# Altium schematic sheet Analog_Interface.SchDoc(Analog_Interface)
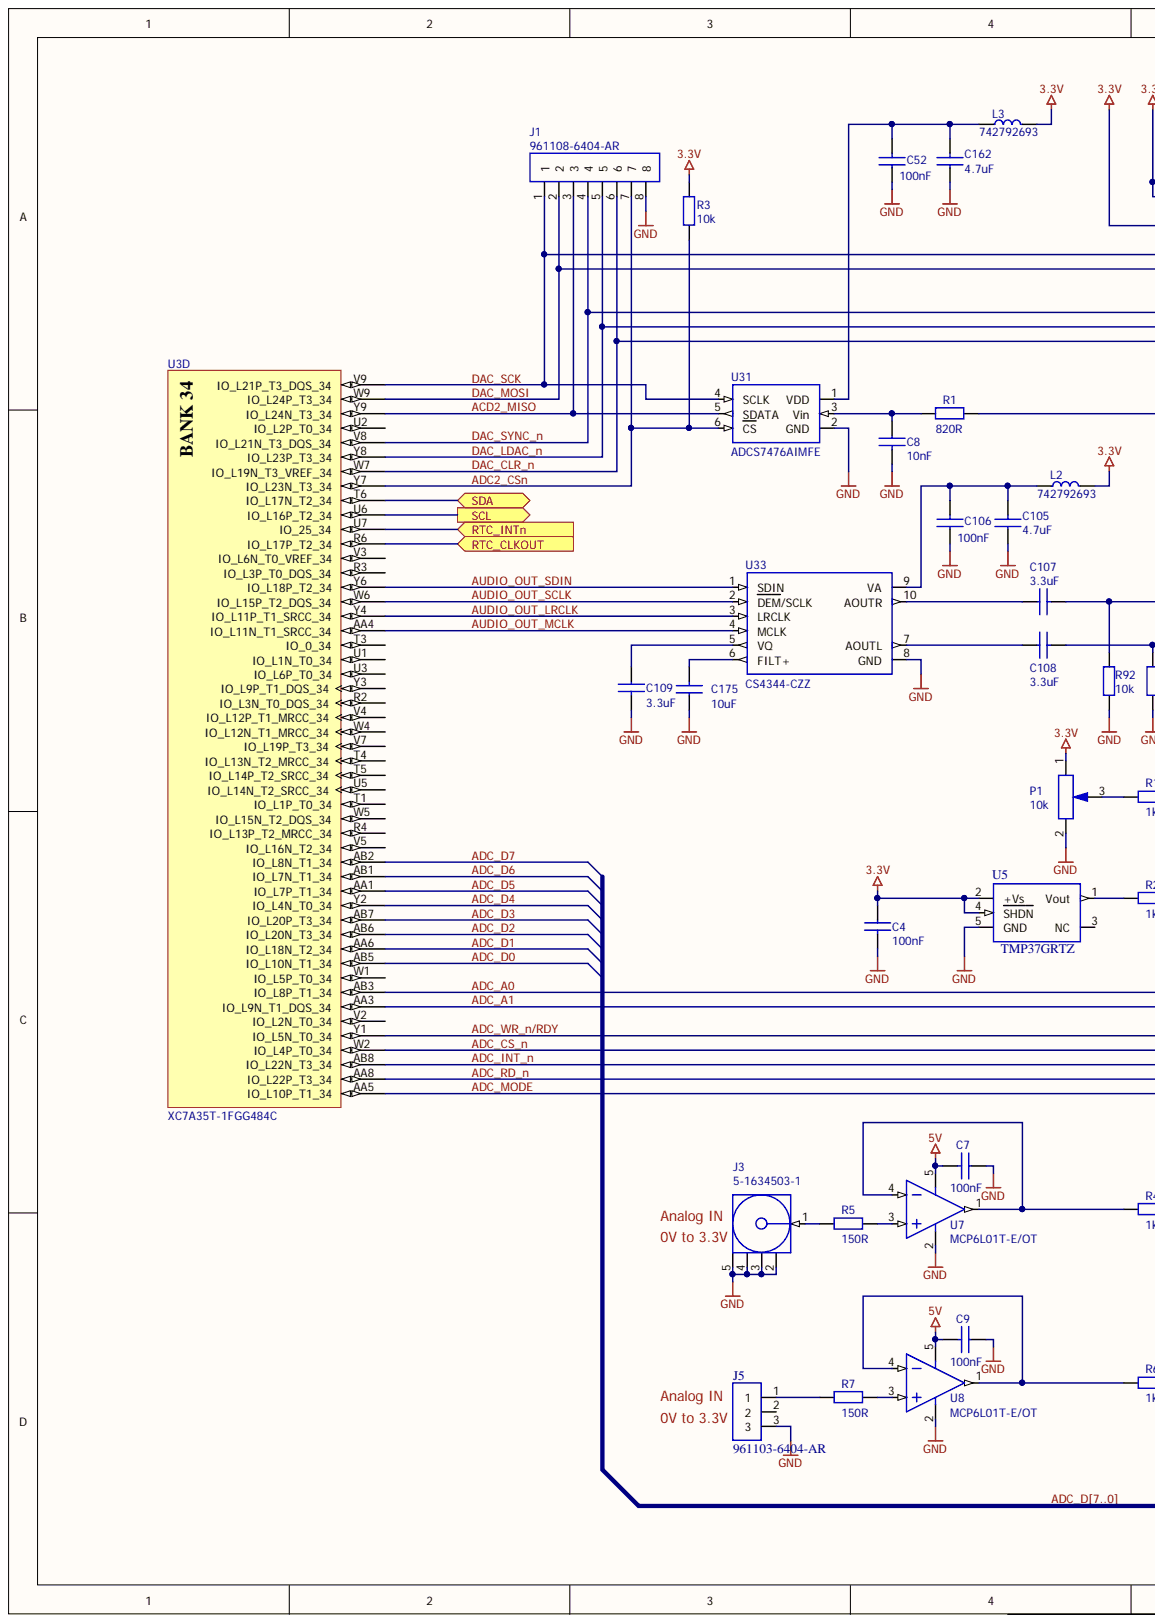
[diagram p0: Analog_Interface.SchDoc, left]
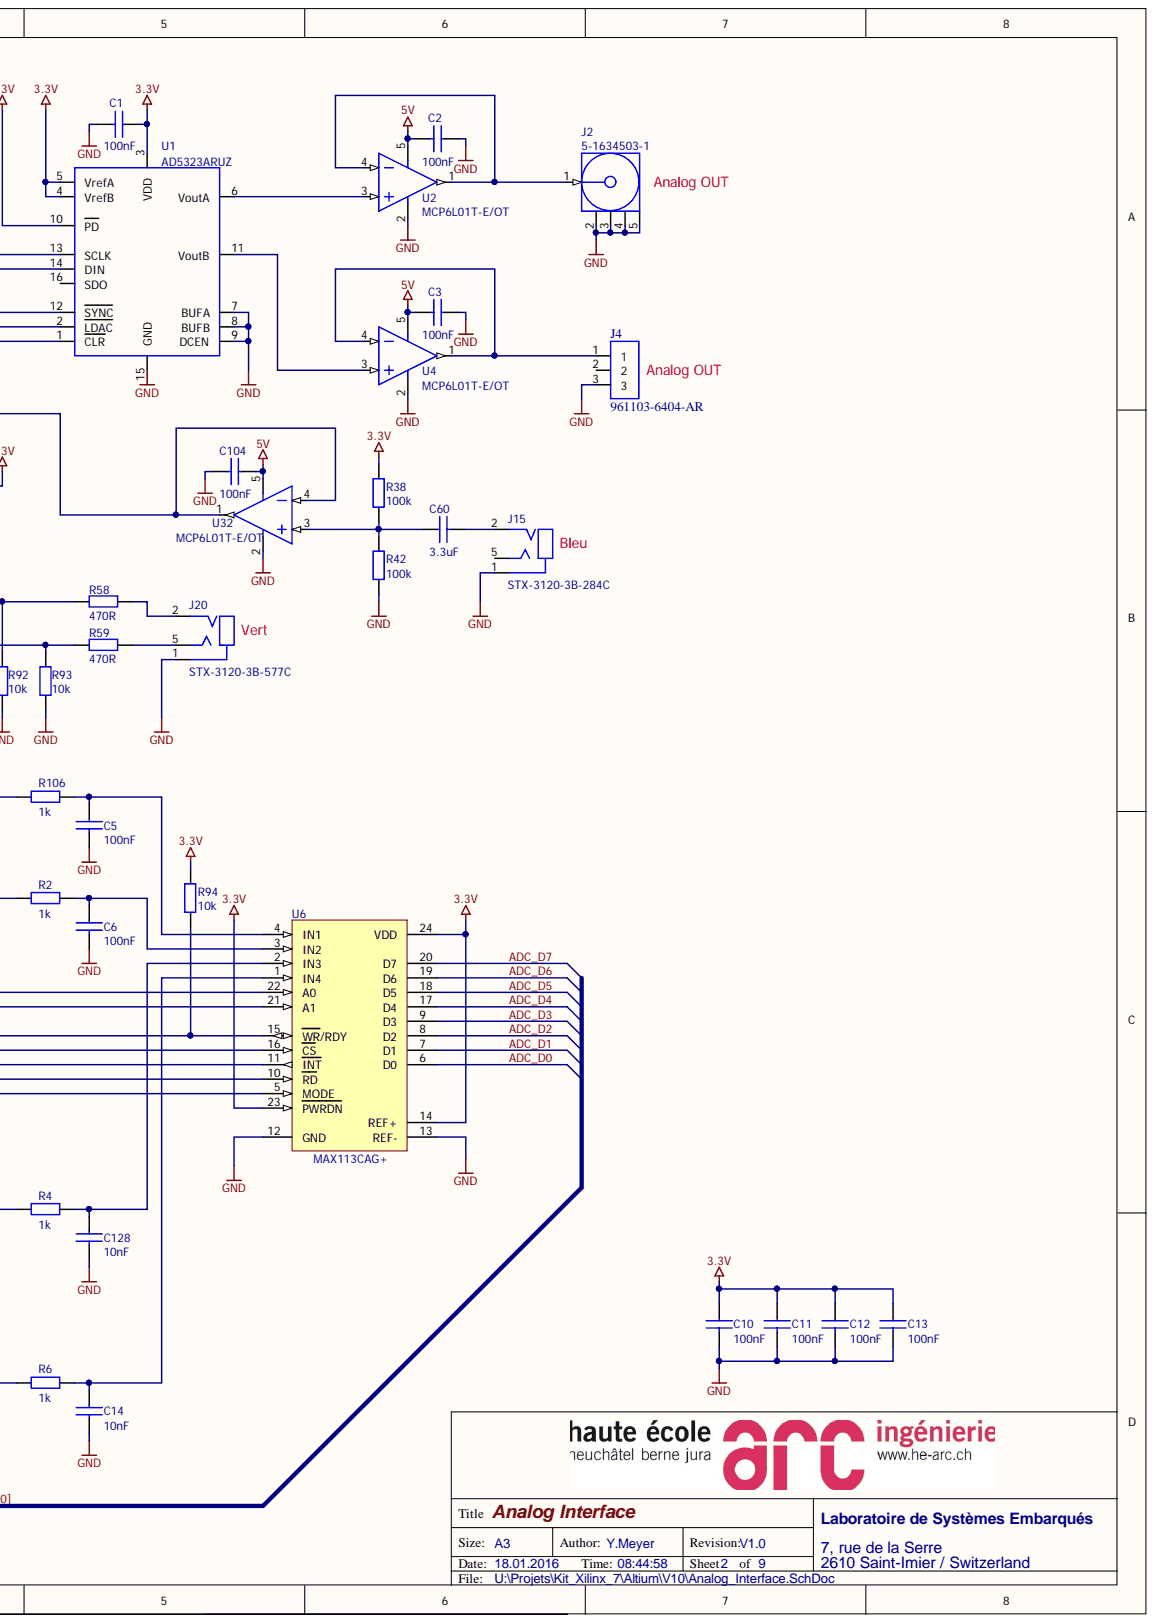
[diagram p1: Analog_Interface.SchDoc, right]

## Parts
C1  value 100nF  [060301]  (hidden comment: C0603C104Z3VAC7867)
C2  value 100nF  [060301]  (hidden comment: C0603C104Z3VAC7867)
C3  value 100nF  [060301]  (hidden comment: C0603C104Z3VAC7867)
C4  value 100nF  [060301]  (hidden comment: C0603C104Z3VAC7867)
C5  value 100nF  [060301]  (hidden comment: C0603C104Z3VAC7867)
C6  value 100nF  [060301]  (hidden comment: C0603C104Z3VAC7867)
C7  value 100nF  [060301]  (hidden comment: C0603C104Z3VAC7867)
C8  value 10nF  [060301]  (hidden comment: ECJ-1VB1H103K)
C9  value 100nF  [060301]  (hidden comment: C0603C104Z3VAC7867)
C10  value 100nF  [060301]  (hidden comment: C0603C104Z3VAC7867)
C11  value 100nF  [060301]  (hidden comment: C0603C104Z3VAC7867)
C12  value 100nF  [060301]  (hidden comment: C0603C104Z3VAC7867)
C13  value 100nF  [060301]  (hidden comment: C0603C104Z3VAC7867)
C14  value 10nF  [060301]  (hidden comment: ECJ-1VB1H103K)
C52  value 100nF  [060301]  (hidden comment: C0603C104Z3VAC7867)
C60  value 3.3uF  [080501]  (hidden comment: C0805C335K8PACTU)
C104  value 100nF  [060301]  (hidden comment: C0603C104Z3VAC7867)
C105  value 4.7uF  [060301]  (hidden comment: C1608X5R0J475M080AB)
C106  value 100nF  [060301]  (hidden comment: C0603C104Z3VAC7867)
C107  value 3.3uF  [080501]  (hidden comment: C0805C335K8PACTU)
C108  value 3.3uF  [080501]  (hidden comment: C0805C335K8PACTU)
C109  value 3.3uF  [080501]  (hidden comment: C0805C335K8PACTU)
C128  value 10nF  [060301]  (hidden comment: ECJ-1VB1H103K)
C162  value 4.7uF  [060301]  (hidden comment: C1608X5R0J475M080AB)
C175  value 10uF  [080501]  (hidden comment: C2012X6S1C106M125AC)
J1  961108-6404-AR  [J108A101]
J2  5-1634503-1  [CON_BNC02]
J3  5-1634503-1  [CON_BNC02]
J4  961103-6404-AR  [J103A01]
J5  961103-6404-AR  [J103A01]
J15  STX-3120-3B-284C  [Jack_audio]
J20  STX-3120-3B-577C  [Jack_audio]
L2  742792693  [060301]
L3  742792693  [060301]
P1  value 10k  [P00101]  (hidden comment: 3224W-1-103E)
R1  value 820R  [060301]  (hidden comment: RC0603FR-07820RL)
R2  value 1k  [060301]  (hidden comment: RC0603FR-071KL)
R3  value 10k  [060301]  (hidden comment: RC0603FR-0710KL)
R4  value 1k  [060301]  (hidden comment: RC0603FR-071KL)
R5  value 150R  [060301]  (hidden comment: RC0603FR-07150RL)
R6  value 1k  [060301]  (hidden comment: RC0603FR-071KL)
R7  value 150R  [060301]  (hidden comment: RC0603FR-07150RL)
R38  value 100k  [060301]  (hidden comment: RC0603FR-07100KL)
R42  value 100k  [060301]  (hidden comment: RC0603FR-07100KL)
R58  value 470R  [060301]  (hidden comment: RC0603FR-07470RL)
R59  value 470R  [060301]  (hidden comment: RC0603FR-07470RL)
R92  value 10k  [060301]  (hidden comment: RC0603FR-0710KL)
R93  value 10k  [060301]  (hidden comment: RC0603FR-0710KL)
R94  value 10k  [060301]  (hidden comment: RC0603FR-0710KL)
R106  value 1k  [060301]  (hidden comment: RC0603FR-071KL)
U1  AD5323ARUZ  [TSSOP16]
U2  MCP6L01T-E/OT  [SOT23-5]
U3  XC7A35T-1FGG484C  [FGG484]  (part D)
U4  MCP6L01T-E/OT  [SOT23-5]
U5  TMP37GRTZ  [SOT23-5]
U6  MAX113CAG+  [SSOP24]
U7  MCP6L01T-E/OT  [SOT23-5]
U8  MCP6L01T-E/OT  [SOT23-5]
U31  ADCS7476AIMFE  [SOT23601]
U32  MCP6L01T-E/OT  [SOT23-5]
U33  CS4344-CZZ  [TSSOP10]

## Ports
RTC_CLKOUT, RTC_INTN, SCL, SDA

## Nets
3.3V: C1.1 C4.1 C10.1 C11.1 C12.1 C13.1 L2.1 L3.1 P1.1 R3.1 R38.1 R94.1 U1.3(VDD) U1.4(VrefB) U1.5(VrefA) U1.10(PD) U5.2(+Vs) U5.4(SHDN) U6.14(REF+) U6.23(PWRDN) U6.24(VDD)
5V: C2.2 C3.2 C7.2 C9.2 C104.1 U2.5 U4.5 U7.5 U8.5 U32.5
GND: C1.2 C2.1 C3.1 C4.2 C5.2 C6.2 C7.1 C8.2 C9.1 C10.2 C11.2 C12.2 C13.2 C14.2 C52.1 C104.2 C105.1 C106.1 C109.2 C128.2 C162.1 C175.1 J1.8 J2.2 J2.3 J2.4 J2.5 J3.2 J3.3 J3.4 J3.5 J4.3 J5.3 J15.1 J20.1 P1.2 R42.2 R92.2 R93.2 U1.7(BUFA) U1.8(BUFB) U1.9(DCEN) U1.15(GND) U2.2 U4.2 U5.5(GND) U6.12(GND) U6.13(REF-) U7.2 U8.2 U31.2(GND) U32.2 U33.8(GND)
RTC_INTN: U3.U7(IO_25_34) ; port RTC_INTN
ACD2_MISO: J1.3 U3.Y9(IO_L24N_T3_34) U31.5(SDATA)
ADC2_CSN: J1.7 R3.2 U3.Y7(IO_L23N_T3_34) U31.6(CS)
ADC_A0: U3.AB3(IO_L8P_T1_34) U6.22(A0)
ADC_A1: U3.AA3(IO_L9N_T1_DQS_34) U6.21(A1)
ADC_CS_N: U3.W2(IO_L4P_T0_34) U6.16(CS)
ADC_D0: U3.AB5(IO_L10N_T1_34) U6.6(D0)  [also labelled ADC_D]
ADC_D1: U3.AA6(IO_L18N_T2_34) U6.7(D1)  [also labelled ADC_D]
ADC_D2: U3.AB6(IO_L20N_T3_34) U6.8(D2)  [also labelled ADC_D]
ADC_D3: U3.AB7(IO_L20P_T3_34) U6.9(D3)  [also labelled ADC_D]
ADC_D4: U3.Y2(IO_L4N_T0_34) U6.17(D4)  [also labelled ADC_D]
ADC_D5: U3.AA1(IO_L7P_T1_34) U6.18(D5)  [also labelled ADC_D]
ADC_D6: U3.AB1(IO_L7N_T1_34) U6.19(D6)  [also labelled ADC_D]
ADC_D7: U3.AB2(IO_L8N_T1_34) U6.20(D7)  [also labelled ADC_D]
ADC_INT_N: U3.AB8(IO_L22N_T3_34) U6.11(INT)
ADC_MODE: U3.AA5(IO_L10P_T1_34) U6.5(MODE)
ADC_RD_N: U3.AA8(IO_L22P_T3_34) U6.10(RD)
ADC_WR_N/RDY: R94.2 U3.Y1(IO_L5N_T0_34) U6.15(WR/RDY)
AUDIO_OUT_LRCLK: U3.Y4(IO_L11P_T1_SRCC_34) U33.3(LRCLK)
AUDIO_OUT_MCLK: U3.AA4(IO_L11N_T1_SRCC_34) U33.4(MCLK)
AUDIO_OUT_SCLK: U3.W6(IO_L15P_T2_DQS_34) U33.2(DEM/SCLK)
AUDIO_OUT_SDIN: U3.Y6(IO_L18P_T2_34) U33.1(SDIN)
DAC_CLR_N: J1.6 U1.1(CLR) U3.W7(IO_L19N_T3_VREF_34)
DAC_LDAC_N: J1.5 U1.2(LDAC) U3.Y8(IO_L23P_T3_34)
DAC_MOSI: J1.2 U1.14(DIN) U3.W9(IO_L24P_T3_34)
DAC_SCK: J1.1 U1.13(SCLK) U3.V9(IO_L21P_T3_DQS_34) U31.4(SCLK)
DAC_SYNC_N: J1.4 U1.12(SYNC) U3.V8(IO_L21N_T3_DQS_34)
RTC_CLKOUT: U3.R6(IO_L17P_T2_34) ; port RTC_CLKOUT
SCL: U3.U6(IO_L16P_T2_34) ; port SCL
SDA: U3.T6(IO_L17N_T2_34) ; port SDA
NetC5_1: C5.1 R106.1 U6.4(IN1)
NetC6_1: C6.1 R2.1 U6.3(IN2)
NetC8_1: C8.1 R1.2 U31.3(Vin)
NetC14_1: C14.1 R6.1 U6.1(IN4)
NetC52_2: C52.2 C162.2 L3.2 U31.1(VDD)
NetC60_1: C60.1 R38.2 R42.1 U32.3
NetC60_2: C60.2 J15.2
NetC105_2: C105.2 C106.2 L2.2 U33.9(VA)
NetC107_1: C107.1 U33.10(AOUTR)
NetC107_2: C107.2 R58.2 R92.1
NetC108_1: C108.1 U33.7(AOUTL)
NetC108_2: C108.2 R59.2 R93.1
NetC109_1: C109.1 U33.5(VQ)
NetC128_1: C128.1 R4.1 U6.2(IN3)
NetC175_2: C175.2 U33.6(FILT+)
NetJ2_1: J2.1 U2.1 U2.4
NetJ3_1: J3.1 R5.2
NetJ4_1: J4.1 U4.1 U4.4
NetJ5_1: J5.1 R7.2
NetJ20_2: J20.2 R58.1
NetJ20_5: J20.5 R59.1
NetP1_3: P1.3 R106.2
NetR1_1: R1.1 U32.1 U32.4
NetR2_2: R2.2 U5.1(Vout)
NetR4_2: R4.2 U7.1 U7.4
NetR5_1: R5.1 U7.3
NetR6_2: R6.2 U8.1 U8.4
NetR7_1: R7.1 U8.3
NetU1_6: U1.6(VoutA) U2.3
NetU1_11: U1.11(VoutB) U4.3

Not connected: J4 2; J5 2; J15 5; U1 16(SDO); U3 R2(IO_L3N_T0_DQS_34) R3(IO_L3P_T0_DQS_34) R4(IO_L13P_T2_MRCC_34) T1(IO_L1P_T0_34) T3(IO_0_34) T4(IO_L13N_T2_MRCC_34) T5(IO_L14P_T2_SRCC_34) U1(IO_L1N_T0_34) U2(IO_L2P_T0_34) U3(IO_L6P_T0_34) U5(IO_L14N_T2_SRCC_34) V2(IO_L2N_T0_34) V3(IO_L6N_T0_VREF_34) V4(IO_L12P_T1_MRCC_34) V5(IO_L16N_T2_34) V7(IO_L19P_T3_34) W1(IO_L5P_T0_34) W4(IO_L12N_T1_MRCC_34) W5(IO_L15N_T2_DQS_34) Y3(IO_L9P_T1_DQS_34); U5 3(NC)

## Library data of the parts
C1-C7 C9-C13 C52 C104 C106 = CAPA: CAP .10UF 25V CERAMIC Y5V 0603; Component Kind: Standard; Supplier 1: Digi-Key; Supplier Part Number 1: 399-1100-2-ND; Library Name: EISI.SchLib
C8 C14 C128 = CAPA: CAP 10000PF 50V CERM X7R 0603; Component Kind: Standard; Supplier 1: Digi-Key; Supplier Part Number 1: PCC1784TR-ND; Library Name: EISI.SchLib
C60 C107-C109 = CAPA: CAP CER 3.3UF 10V X5R 0805; Component Kind: Standard; Supplier 1: Digi-Key; Supplier Part Number 1: 399-3129-1-ND; Library Name: EISI.SchLib
C105 C162 = CAPA: CAP CER 4.7UF 6.3V 20% X5R 0603; Component Kind: Standard; Supplier 1: Digi-Key; Supplier Part Number 1: 445-1417-2-ND; Library Name: EISI.SchLib
C175 = CAPA: CAP CER 10UF 16V 20% X6S 0805; Component Kind: Standard; Supplier 1: Digi-Key; Supplier Part Number 1: 445-7612-2-ND; Library Name: EISI.SchLib
J1 = CON8: CONN HEADER VERT SGL 8POS GOLD; Component Kind: Standard; Supplier 1: Digi-Key; Supplier Part Number 1: 3M9452-ND; Library Name: EISI.SchLib
J2 J3 = CON SMA: CONN BNC JACK STR 50 OHM PCB; Component Kind: Standard; Supplier 1: Digi-Key; Supplier Part Number 1: A97581-ND; Library Name: EISI.SchLib
J4 J5 = CON3: CONN HEADER VERT SGL 3POS GOLD; Component Kind: Standard; Supplier 1: Digi-Key; Supplier Part Number 1: 3M9448-ND; Library Name: EISI.SchLib
J15 = Jack_audio: Phone Connectors 3.5mm PCB STEREO JAK 3P ALL PLASTIC BLUE; Component Kind: Standard; Library Name: KitXilinx7.SCHLIB; Supplier 1: Mouser; Supplier Part Number 1: 806-STX-31203B284C
J20 = Jack_audio: Phone Connectors 3.5mm PCB STEREO JAK 3P ALL PLASTIC GREEN; Component Kind: Standard; Library Name: KitXilinx7.SCHLIB; Supplier 1: Mouser; Supplier Part Number 1: 806-STX-31203B577C
L2 L3 = INDUCTOR: FERRITE BEAD 2200 OHM .05A 0603; Component Kind: Standard; Supplier 1: Digi-Key; Supplier Part Number 1: 732-1588-1-ND
P1 = POT: TRIMPOT 10K OHM 4MM TOP ADJ SMD; Component Kind: Standard; Supplier 1: Digi-Key; Supplier Part Number 1: 3224W-103ETR-ND; Library Name: EISI.SchLib
R1 = RES: RES SMD 820 OHM 1% 1/10W 0603; Component Kind: Standard; Supplier 1: Digi-Key; Supplier Part Number 1: 311-820HRCT-ND; Library Name: EISI.SchLib
R2 R4 R6 R106 = RES: RES SMD 1K OHM 1% 1/10W 0603; Component Kind: Standard; Supplier 1: Digi-Key; Supplier Part Number 1: 311-1.00KHRCT-ND; Library Name: EISI.SchLib
R3 R92-R94 = RES: RES 10.0K OHM 1/10W 1% 0603 SMD; Component Kind: Standard; Supplier 1: Digi-Key; Supplier Part Number 1: 311-10.0KHRCT-ND; Library Name: EISI.SchLib
R5 R7 = RES: RES 150 OHM 1/10W 1% 0603 SMD; Component Kind: Standard; Supplier 1: Digi-Key; Supplier Part Number 1: 311-150HRTR-ND; Library Name: EISI.SchLib
R38 R42 = RES: RES SMD 100K OHM 1% 1/10W 0603; Component Kind: Standard; Supplier 1: Digi-Key; Supplier Part Number 1: 311-100KHRCT-ND; Library Name: EISI.SchLib
R58 R59 = RES: RES SMD 470 OHM 1% 1/10W 0603; Component Kind: Standard; Supplier 1: Digi-Key; Supplier Part Number 1: 311-470HRCT-ND; Library Name: EISI.SchLib
U1 = AD5303: IC DAC 12BIT DUAL R-R 16-TSSOP; Component Kind: Standard; Supplier 1: Digi-Key; Supplier Part Number 1: AD5323ARUZ-ND; Library Name: EISI.SchLib
U2 U4 U7 U8 U32 = MAX4040EUK-T: IC OPAMP GP 1MHZ RRO SOT23-5; Component Kind: Standard; Simulation: MAX4104; Supplier 1: Digi-Key; Supplier Part Number 1: MCP6L01T-E/OTCT-ND; Library Name: EISI.SchLib
U3 = XC7A35T-1FGG484C: Artix-7 FPGA, 250 User I/Os, 4 GTP, 484-Ball BGA, Speed Grade 1, Commercial Grade, Pb-Free; Manufacturer 1: Xilinx; Component Kind: Standard; Library Name: KitXilinx7.SCHLIB; Manufacturer Part Number 1: XC7A35T-1FGG484C; Supplier 1: Avnet Express; Supplier Part Number 1: XC7A35T-1FGG484C; Supplier Subtotal 1: 2140; Supplier Unit Price 1: 52.1952
U5 = TMP35: IC TEMP SENSOR CENTIGRD SOT23-5; Component Kind: Standard; Supplier 1: Digi-Key; Supplier Part Number 1: TMP37GRTZ-REEL7CT-ND; Library Name: EISI.SchLib
U6 = MAX114CAG: +5V, 1Msps, 4-Channel, 8-Bit ADCs with 1µA Power-Down; Component Kind: Standard; Library Name: Maxim Converter Analog to Digital.IntLib; PCB3D: SSOP24; Supplier 1: Digi-Key; Supplier Part Number 1: MAX113CAG+-ND
U31 = ADCS7476AIMFE: IC ADC 12BIT 1MSPS SOT-23-6; Component Kind: Standard; Library Name: KitXilinx7.SCHLIB; Supplier 1: Digi-Key; Supplier Part Number 1: ADCS7476AIMF/NOPBCT-ND
U33 = CS4344-CZZ: IC DAC STER 24BIT 192KHZ 10TSSOP; Component Kind: Standard; Library Name: KitXilinx7.SCHLIB; Supplier 1: Digi-Key; Supplier Part Number 1: 598-1051-5-ND
